# Altium schematic sheet Power system 1.SchDoc
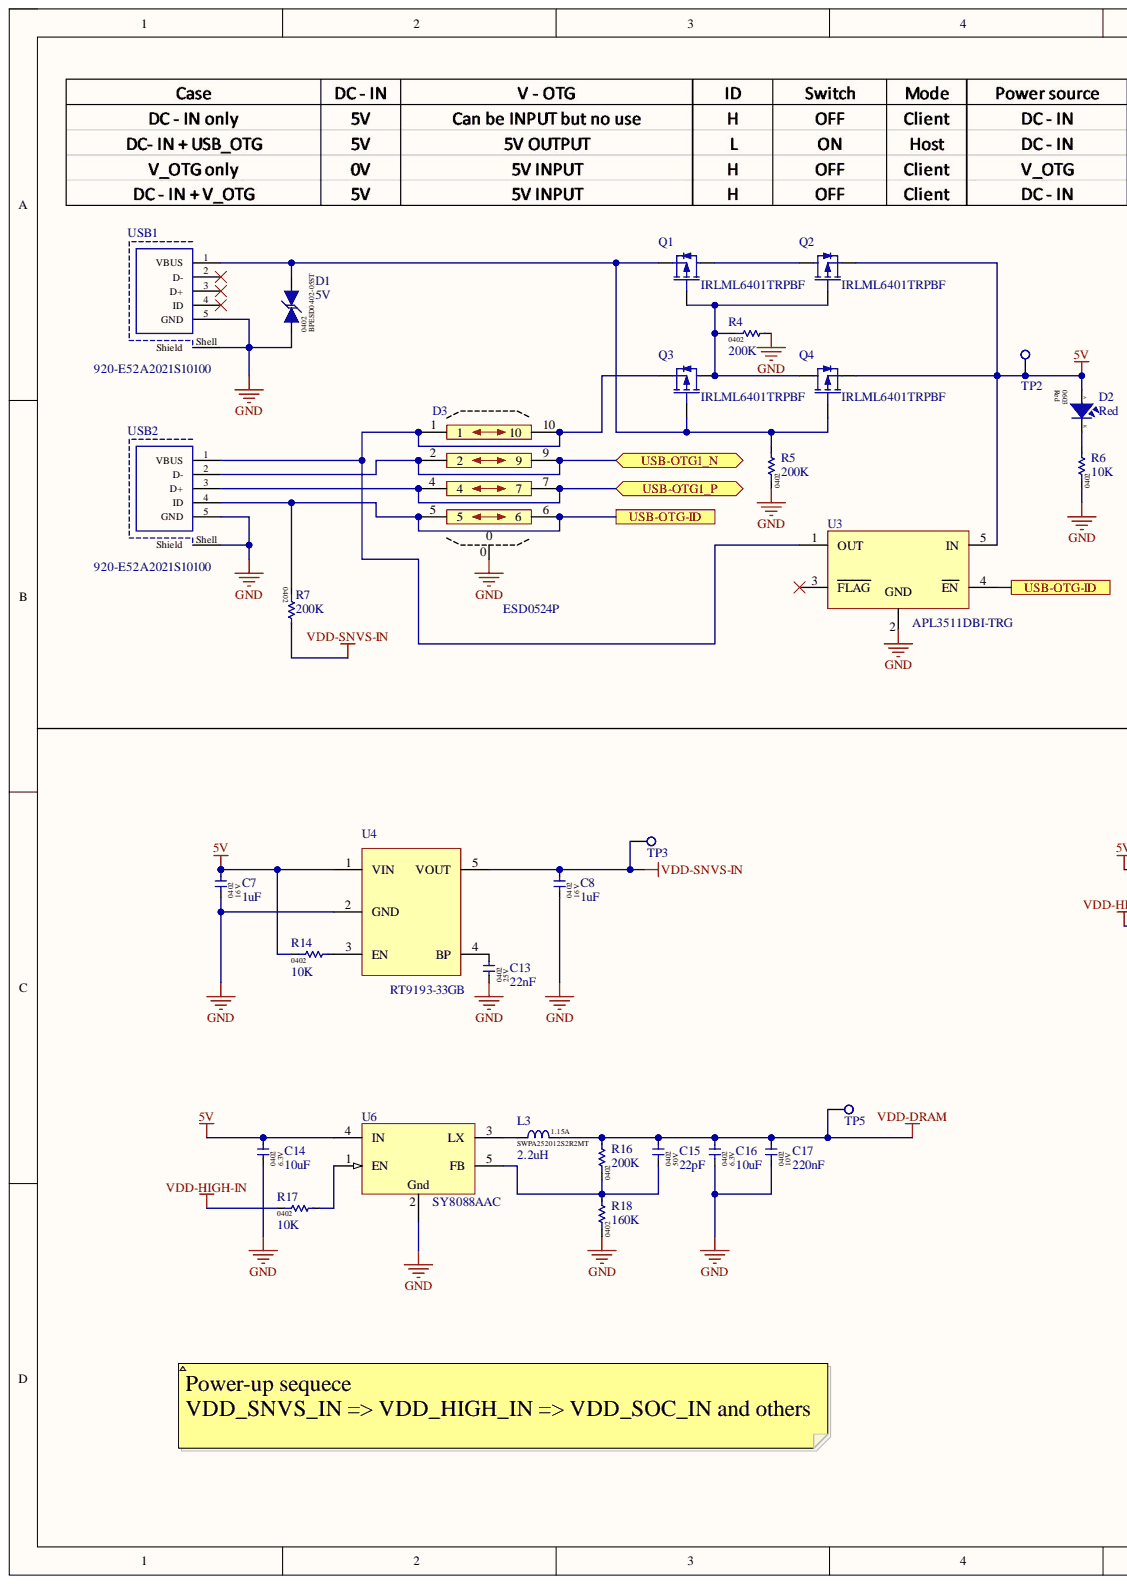
[diagram p0: Power system 1.SchDoc, left]
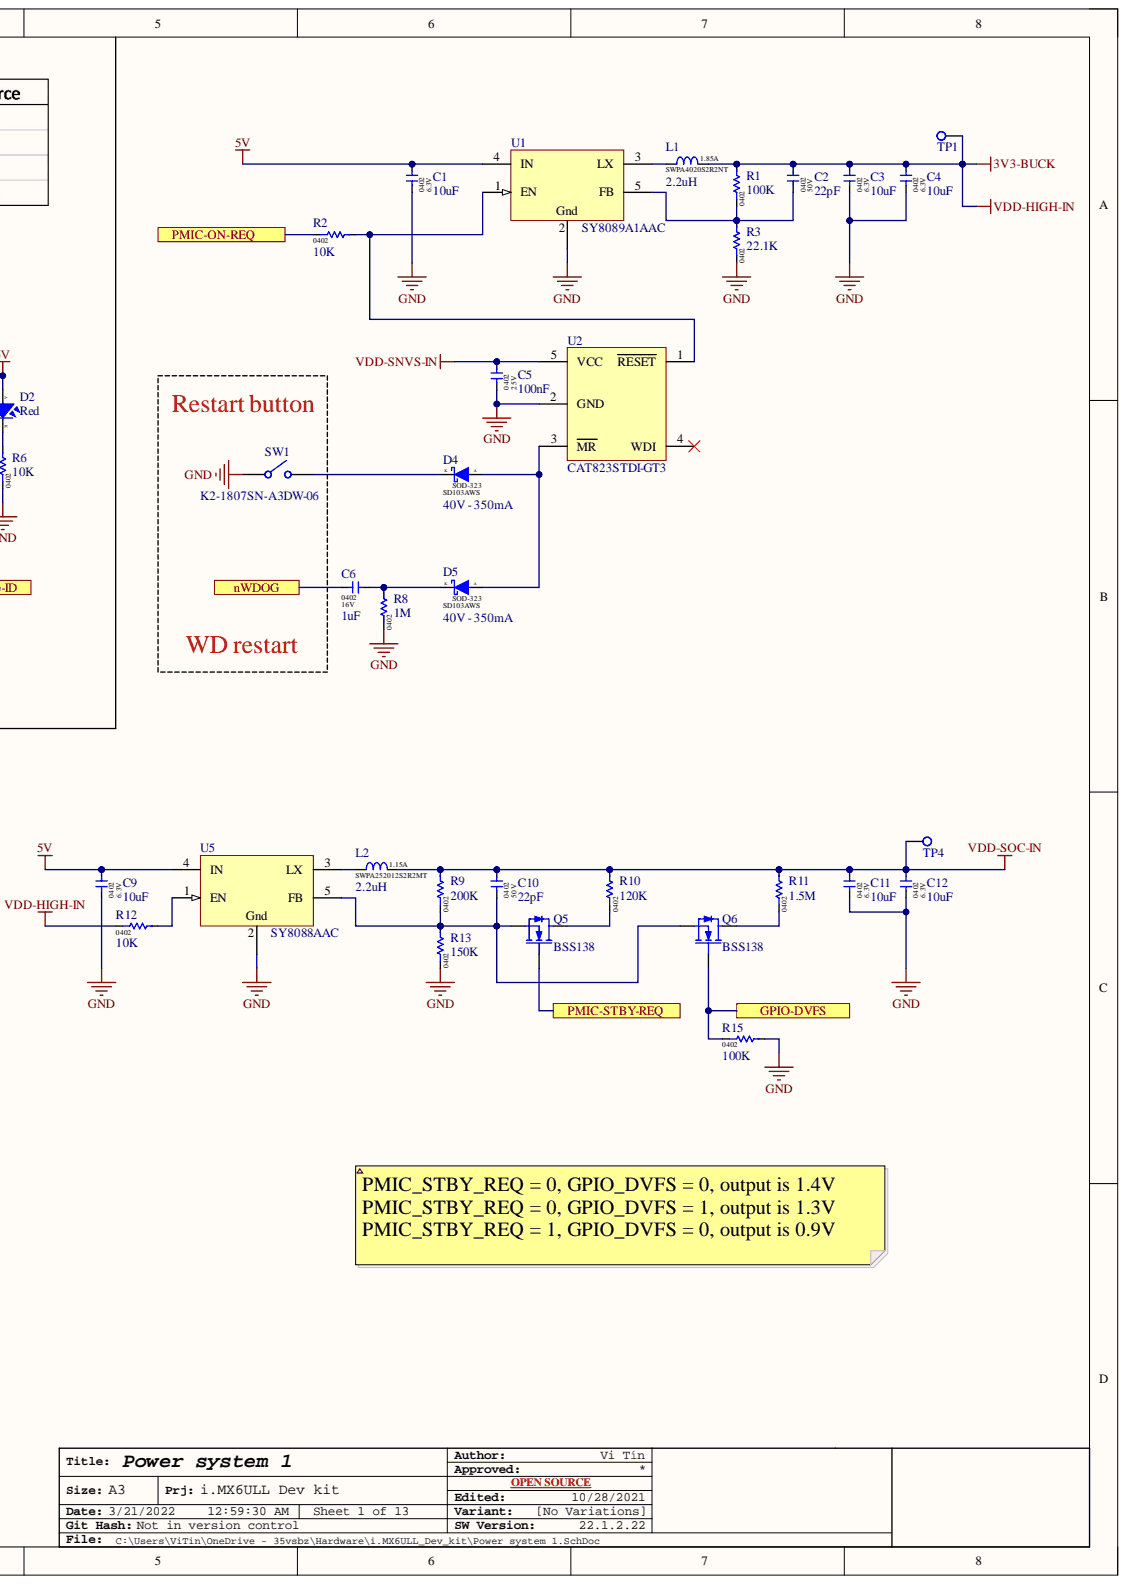
[diagram p1: Power system 1.SchDoc, right]

## Parts
C1  10uF  Package: 0402  Voltage: 6.3V  [CAP-0402]
C2  22pF  Package: 0402  Voltage: 50V  [CAP-0402]
C3  10uF  Package: 0402  Voltage: 6.3V  [CAP-0402]
C4  10uF  Package: 0402  Voltage: 6.3V  [CAP-0402]
C5  100nF  Package: 0402  Voltage: 25V  [CAP-0402]
C6  1uF  Package: 0402  Voltage: 16V  [CAP-0402]
C7  1uF  Package: 0402  Voltage: 16V  [CAP-0402]
C8  1uF  Package: 0402  Voltage: 16V  [CAP-0402]
C9  10uF  Package: 0402  Voltage: 6.3V  [CAP-0402]
C10  22pF  Package: 0402  Voltage: 50V  [CAP-0402]
C11  10uF  Package: 0402  Voltage: 6.3V  [CAP-0402]
C12  10uF  Package: 0402  Voltage: 6.3V  [CAP-0402]
C13  22nF  Package: 0402  Voltage: 25V  [CAP-0402]
C14  10uF  Package: 0402  Voltage: 6.3V  [CAP-0402]
C15  22pF  Package: 0402  Voltage: 50V  [CAP-0402]
C16  10uF  Package: 0402  Voltage: 6.3V  [CAP-0402]
C17  220nF  Package: 0402  Voltage: 10V  [CAP-0402]
D1  5V  Package: 0402  [Diode-0402]
D2  Red  Package: 0603  [LED-0603 RED]
D3  ESD0524P  [DFN2510]
D4  40V - 350mA  Package: SOD-323  [SOD323 (UNI)]
D5  40V - 350mA  Package: SOD-323  [SOD323 (UNI)]
L1  2.2uH  Irms max: 1.85A  [IND-4020]
L2  2.2uH  Irms max: 1.15A  [IND-252012]
L3  2.2uH  Irms max: 1.15A  [IND-252012]
Q1  IRLML6401TRPBF  [SOT23-3]
Q2  IRLML6401TRPBF  [SOT23-3]
Q3  IRLML6401TRPBF  [SOT23-3]
Q4  IRLML6401TRPBF  [SOT23-3]
Q5  BSS138  [SOT23-3]
Q6  BSS138  [SOT23-3]
R1  100K  Package: 0402  [RES-0402]
R2  10K  Package: 0402  [RES-0402]
R3  22.1K  Package: 0402  [RES-0402]
R4  200K  Package: 0402  [RES-0402]
R5  200K  Package: 0402  [RES-0402]
R6  10K  Package: 0402  [RES-0402]
R7  200K  Package: 0402  [RES-0402]
R8  1M  Package: 0402  [RES-0402]
R9  200K  Package: 0402  [RES-0402]
R10  120K  Package: 0402  [RES-0402]
R11  1.5M  Package: 0402  [RES-0402]
R12  10K  Package: 0402  [RES-0402]
R13  150K  Package: 0402  [RES-0402]
R14  10K  Package: 0402  [RES-0402]
R15  100K  Package: 0402  [RES-0402]
R16  200K  Package: 0402  [RES-0402]
R17  10K  Package: 0402  [RES-0402]
R18  160K  Package: 0402  [RES-0402]
SW1  K2-1807SN-A3DW-06  [SW - TYPE 3]
TP1  N/A  [Test point]  (value not drawn)  (no BOM)
TP2  N/A  [Test point]  (value not drawn)  (no BOM)
TP3  N/A  [Test point]  (value not drawn)  (no BOM)
TP4  N/A  [Test point]  (value not drawn)  (no BOM)
TP5  N/A  [Test point]  (value not drawn)  (no BOM)
U1  SY8089A1AAC  [SOT23-5]
U2  CAT823STDI-GT3  [SOT23-5]
U3  APL3511DBI-TRG  [SOT23-5]
U4  RT9193-33GB  [SOT23-5]
U5  SY8088AAC  [SOT23-5]
U6  SY8088AAC  [SOT23-5]
USB1  920-E52A2021S10100  [Micro USB - type 2]
USB2  920-E52A2021S10100  [Micro USB - type 2]

## Ports
GPIO-DVFS, nWDOG, PMIC-ON-REQ, PMIC-STBY-REQ, USB-OTG1_N, USB-OTG1_P, USB-OTG-ID

## Nets
3V3-BUCK: C2.2 C3.2 C4.2 L1.2 R1.2 R12.1 R17.1 TP1.1
5V: C1.2 C7.2 C9.2 C14.2 D2.2 Q2.2 Q4.2 R14.1 TP2.1 U1.4(IN) U3.5(IN) U4.1(VIN) U5.4(IN) U6.4(IN)
GND: C1.1 C3.1 C4.1 C5.1 C7.1 C8.1 C9.1 C11.1 C12.1 C13.1 C14.1 C16.1 C17.1 D1.1 D3.0 R3.1 R4.2 R5.1 R6.1 R8.1 R13.1 R15.2 R18.1 SW1.1 U1.2 U2.2(GND) U3.2 U4.2(GND) U5.2 U6.2 USB1.5(GND) USB1.Shell(Shield) USB2.5(GND) USB2.Shell(Shield)
VDD-DRAM: C15.2 C16.2 C17.2 L3.2 R16.2 TP5.1
VDD-SNVS-IN: C5.2 C8.2 R7.2 TP3.1 U2.5(VCC) U4.5(VOUT)
VDD-SOC-IN: C10.2 C11.2 C12.2 L2.2 R9.2 R10.2 R11.2 TP4.1
GPIO-DVFS: Q6.1 R15.1 ; port GPIO-DVFS
nWDOG: C6.1 ; port nWDOG
PMIC-ON-REQ: R2.1 ; port PMIC-ON-REQ
PMIC-STBY-REQ: Q5.1 ; port PMIC-STBY-REQ
USB-OTG1_N: D3.2 D3.9 USB2.2(D-) ; port USB-OTG1_N
USB-OTG1_P: D3.4 D3.7 USB2.3(D+) ; port USB-OTG1_P
USB-OTG-ID: D3.5 D3.6 R7.1 U3.4(EN) USB2.4(ID) ; port USB-OTG-ID
NetC2_1: C2.1 R1.1 R3.2 U1.5(FB)
NetC6_2: C6.2 D5.1 R8.2
NetC10_1: C10.1 Q5.2 Q6.2 R9.1 R13.2 U5.5(FB)
NetC13_2: C13.2 U4.4(BP)
NetC15_1: C15.1 R16.1 R18.2 U6.5(FB)
NetD1_2: D1.2 Q1.2 Q3.1 Q4.1 R5.2 USB1.1(VBUS)
NetD2_1: D2.1 R6.2
NetD3_1: D3.1 D3.10 Q3.2 U3.1(OUT) USB2.1(VBUS)
NetD4_1: D4.1 SW1.2
NetD4_2: D4.2 D5.2 U2.3(MR)
NetL1_1: L1.1 U1.3(LX)
NetL2_1: L2.1 U5.3(LX)
NetL3_1: L3.1 U6.3(LX)
NetQ1_1: Q1.1 Q2.1 Q3.3 Q4.3 R4.1
NetQ1_3: Q1.3 Q2.3
NetQ5_3: Q5.3 R10.1
NetQ6_3: Q6.3 R11.1
NetR2_2: R2.2 U1.1(EN) U2.1(RESET)
NetR12_2: R12.2 U5.1(EN)
NetR14_2: R14.2 U4.3(EN)
NetR17_2: R17.2 U6.1(EN)

Not connected: U2 4(WDI); U3 3(FLAG); USB1 2(D-) 3(D+) 4(ID)

## Library data of the parts
C1 C3 C4 C9 C11 C12 C14 C16 = 0402X106M6R3CT: 10uF ±20% 6.3V X5R 0402 Multilayer Ceramic Capacitors MLCC - SMD/SMT RoHS; Manufacture: WALSIN; Database Table Name: Sheet1$; Capacitor value: 10uF; Component Kind: Standard; Component type: Capacitor; Library Name: Capacitor Database.DbLib; Manufacture part: 0402X106M6R3CT; Package / Case: 0402
C2 C10 C15 = 0402N220J500CT: 22pF ±5% 50V NP0 0402 Multilayer Ceramic Capacitors MLCC - SMD/SMT RoHS; Manufacture: WALSIN; Database Table Name: Sheet1$; Capacitor value: 22pF; Component Kind: Standard; Component type: Capacitor; Library Name: Capacitor Database.DbLib; Manufacture part: 0402N220J500CT; Package / Case: 0402
C5 = 0402X104K250CT: 100nF ±10% 25V X5R 0402 Multilayer Ceramic Capacitors MLCC - SMD/SMT RoHS; Manufacture: WALSIN; Database Table Name: Sheet1$; Capacitor value: 100nF; Component Kind: Standard; Component type: Capacitor; Library Name: Capacitor Database.DbLib; Manufacture part: 0402X104K250CT; Package / Case: 0402
C6-C8 = 0402X105K160CT: 1uF ±10% 16V X5R 0402 Multilayer Ceramic Capacitors MLCC - SMD/SMT RoHS; Manufacture: WALSIN; Database Table Name: Sheet1$; Capacitor value: 1uF; Component Kind: Standard; Component type: Capacitor; Library Name: Capacitor Database.DbLib; Manufacture part: 0402X105K160CT; Package / Case: 0402
C13 = 0402B223K250CT: 22nF ±10% 25V X7R 0402 Multilayer Ceramic Capacitors MLCC - SMD/SMT RoHS; Manufacture: WALSIN; Database Table Name: Sheet1$; Capacitor value: 22nF; Component Kind: Standard; Component type: Capacitor; Library Name: Capacitor Database.DbLib; Manufacture part: 0402B223K250CT; Package / Case: 0402
C17 = 0402X224J100CT: 220nF ±5% 10V X5R 0402 Multilayer Ceramic Capacitors MLCC - SMD/SMT RoHS; Manufacture: WALSIN; Database Table Name: Sheet1$; Capacitor value: 220nF; Component Kind: Standard; Component type: Capacitor; Library Name: Capacitor Database.DbLib; Manufacture part: 0402X224J100CT; Package / Case: 0402
D1 = BPESD0402-05ST: Bidirectional 40V 5V (Max) 0402 ESD Protection Devices ROHS; Manufacture: BORN; Database Table Name: Sheet1$; Type: TVS/ESD Bi diode; Component Kind: Standard; Datasheet: https://datasheet.lcsc.com/lcsc/1811031631_BORN-BPESD0402-05ST_C316059.pdf; Diode value: 5V; Library Name: Diode Database.DbLib; Manufacture part: BPESD0402-05ST
D2 = 19-217/R6C-AL1M2VY/3T: Red 617.5~633.5nm 0603 Light Emitting Diodes (LED) RoHS; Manufacture: Everlight Elec; Database Table Name: Sheet1$; Color: Red; Component Kind: Standard; Component type: Led; Library Name: Led Database.DbLib; Manufacture part: 19-217/R6C-AL1M2VY/3T; Package / Case: 0402
D3 = ESD0524P: DFN2510 ESD Protection Devices ROHS; Manufacture: MDD（Microdiode Electronics）; Database Table Name: Sheet1$; Diode value: 5V; Type: TVS/ESD Bi diode; Component Kind: Standard; Datasheet: https://datasheet.lcsc.com/lcsc/2009281808_MDD-Microdiode-Electronics--ESD0524P_C840786.pdf; Library Name: Diode Database.DbLib; Manufacture part: ESD0524P; Package: DFN2510
D4 D5 = SD103AWS: 40V 350mA 600mV @ 200mA SOD-323 Schottky Barrier Diodes (SBD) ROHS; Manufacture: Shikues; Database Table Name: Sheet1$; Type: Schottky diode; Component Kind: Standard; Datasheet: https://datasheet.lcsc.com/lcsc/1809211226_Shikues-SD103AWS_C109204.pdf; Diode value: 40V - 350mA; Library Name: Diode Database.DbLib; Manufacture part: SD103AWS
L1 = SWPA4020S2R2NT: Inductor 40x40x20, 2.2±30%  , 1.85A; Manufacture: SUNLORD; Database Table Name: Sheet1$; DCR: 0.04 Ω; Component Kind: Standard; Inductance value: 2.2uH; Library Name: Inductor Database.DbLib; Manufacture part: SWPA4020S2R2NT; Package: 4020
L2 L3 = SWPA252012S2R2MT: Inductor 25x20x12,  2.2±20%, 1.15A; Manufacture: SUNLORD; Database Table Name: Sheet1$; DCR: 0.216 Ω; Component Kind: Standard; Inductance value: 2.2uH; Library Name: Inductor Database.DbLib; Manufacture part: SWPA252012S2R2MT; Package: 252012
Q1-Q4 = IRLML6401TRPBF: P-Channel 12V 4.3A 950mV @ 250uA 50mΩ @ 4.3A,4.5V 1.3W SOT-23(SOT-23-3) MOSFETs RoHS; Manufacture: Infineon Technologies; Database Table Name: Sheet1$; Type: P-Mosfet; Component Kind: Standard; Datasheet: https://datasheet.lcsc.com/lcsc/International-Rectifier-IR-IRLML6401TRPBF_C3034.pdf; Library Name: Transistor Database.DbLib; Manufacture part: IRLML6401TRPBF; Package: SOT23-3
Q5 Q6 = BSS138: N-Channel 50V 220mA 1.5V @ 1mA 3.5Ω @ 220mA,10V 360mW SOT-23(SOT-23-3) MOSFETs RoHS; Manufacture: Shikues; Database Table Name: Sheet1$; Type: N-Mosfet; Component Kind: Standard; Datasheet: https://datasheet.lcsc.com/lcsc/1811101310_Shikues-BSS138_C112239.pdf; Library Name: Transistor Database.DbLib; Manufacture part: BSS138; Package: SOT23-3
R1 R15 = WR04X1003FTL: 100kΩ ±1% 1/16W ±100ppm/℃ 0402 Chip Resistor - Surface Mount RoHS; Manufacture: WALSIN; Database Table Name: Sheet1$; Component Kind: Standard; Component type: Resistor; Library Name: Resistor Database.DbLib; Manufacture part: WR04X1003FTL; Package / Case: 0201; Resistor value: 100K
R2 R6 R12 R14 R17 = WR04X1002FTL: 10kΩ ±1% 1/16W ±100ppm/℃ 0402 Chip Resistor - Surface Mount RoHS; Manufacture: WALSIN; Database Table Name: Sheet1$; Component Kind: Standard; Component type: Resistor; Library Name: Resistor Database.DbLib; Manufacture part: WR04X1002FTL; Package / Case: 0201; Resistor value: 10K
R3 = WR04X2212FTL: 22.1kΩ ±1% 1/16W ±100ppm/℃ 0402 Chip Resistor - Surface Mount RoHS; Manufacture: WALSIN; Database Table Name: Sheet1$; Component Kind: Standard; Component type: Resistor; Library Name: Resistor Database.DbLib; Manufacture part: WR04X2212FTL; Package / Case: 0201; Resistor value: 22.1K
R4 R5 R7 R9 R16 = WR04X2003FTL: 200kΩ ±1% 1/16W ±100ppm/℃ 0402 Chip Resistor - Surface Mount RoHS; Manufacture: WALSIN; Database Table Name: Sheet1$; Component Kind: Standard; Component type: Resistor; Library Name: Resistor Database.DbLib; Manufacture part: WR04X2003FTL; Package / Case: 0201; Resistor value: 200K
R8 = WR04X1004FTL: 1MΩ ±1% 1/16W ±100ppm/℃ 0402 Chip Resistor - Surface Mount RoHS; Manufacture: WALSIN; Database Table Name: Sheet1$; Component Kind: Standard; Component type: Resistor; Library Name: Resistor Database.DbLib; Manufacture part: WR04X1004FTL; Package / Case: 0201; Resistor value: 1M
R10 = WR04X1203FTL: 120kΩ ±1% 1/16W ±100ppm/℃ 0402 Chip Resistor - Surface Mount RoHS; Manufacture: WALSIN; Database Table Name: Sheet1$; Component Kind: Standard; Component type: Resistor; Library Name: Resistor Database.DbLib; Manufacture part: WR04X1203FTL; Package / Case: 0201; Resistor value: 120K
R11 = WR04W1504FTL: 1.5MΩ ±1% 1/16W ±100ppm/℃ 0402 Chip Resistor - Surface Mount RoHS; Manufacture: WALSIN; Database Table Name: Sheet1$; Component Kind: Standard; Component type: Resistor; Library Name: Resistor Database.DbLib; Manufacture part: WR04W1504FTL; Package / Case: 0201; Resistor value: 1.5M
R13 = WR04X1503FTL: 150kΩ ±1% 1/16W ±100ppm/℃ 0402 Chip Resistor - Surface Mount RoHS; Manufacture: WALSIN; Database Table Name: Sheet1$; Component Kind: Standard; Component type: Resistor; Library Name: Resistor Database.DbLib; Manufacture part: WR04X1503FTL; Package / Case: 0201; Resistor value: 150K
R18 = WR04X1603FTL: 160kΩ ±1% 1/16W ±100ppm/℃ 0402 Chip Resistor - Surface Mount RoHS; Manufacture: WALSIN; Database Table Name: Sheet1$; Component Kind: Standard; Component type: Resistor; Library Name: Resistor Database.DbLib; Manufacture part: WR04X1603FTL; Package / Case: 0201; Resistor value: 160K
SW1 = K2-1807SN-A3DW-06: SMD,3×4×2.0mm Tactile Switches RoHS; Manufacture: Korean Hroparts Elec; Database Table Name: Sheet1$; Component Kind: Standard; Datasheet: https://datasheet.lcsc.com/lcsc/2008221234_XUNPU-TS-1088-AR02016_C720477.pdf; Library Name: Connectors, Misc.DbLib; Manufacture part: K2-1807SN-A3DW-06; Type: Tactile Switches
TP1-TP5 = N/A: A point used for measure.; Database Table Name: Sheet1$; Library Name: Connectors, Misc.DbLib; Manufacture: N/A; Manufacture part: N/A; Type: Test point
U1 = SY8089A1AAC: 5.5V 2A SOT-23-5 DC-DC Converters RoHS; Manufacture: Richtek Tech; Database Table Name: Sheet1$; Component Kind: Standard; Datasheet: https://datasheet.lcsc.com/lcsc/2001171834_Silergy-Corp-SY8089A1AAC_C479074.pdf; Library Name: Regulator Database.DbLib; Manufacture part: SY8089A1AAC; Package: SOT23-5; Type: Buck
U2 = CAT823STDI-GT3: 2.93V Monitors & Reset Circuits with manual reset and watchdog; Manufacture: ON Semiconductor; Database Table Name: Sheet1$; Component Kind: Standard; Datasheet: https://datasheet.lcsc.com/lcsc/ON-Semicon-ON-CAT823STDI-GT3_C91362.pdf; Library Name: IC Database.DbLib; Manufacture part: CAT823STDI-GT3; Package: SOT23-5
U3 = APL3511DBI-TRG: 5.5V, 1.5A Power Distribution Switches ROHS; Manufacture: Anpec Elec; Database Table Name: Sheet1$; Component Kind: Standard; Datasheet: https://datasheet.lcsc.com/lcsc/1912111437_Anpec-Elec-APL3511DBI-TRG_C351148.pdf; Library Name: IC Database.DbLib; Manufacture part: APL3511DBI-TRG; Package: SOT23-5
U4 = RT9193-33GB: Fixed 5.5V 3.3V 300mV @ 300mA SOT-23-5 Dropout Regulators(LDO) RoHS; Manufacture: Richtek Tech; Database Table Name: Sheet1$; Component Kind: Standard; Datasheet: https://datasheet.lcsc.com/lcsc/Richtek-Tech-RT9193-28GB_C56731.pdf; Library Name: Regulator Database.DbLib; Manufacture part: RT9193-33GB; Package: SOT23-5; Type: LDO
U5 U6 = SY8088AAC: 5.5V 1A SOT-23-5 DC-DC Converters RoHS; Manufacture: Richtek Tech; Database Table Name: Sheet1$; Component Kind: Standard; Datasheet: https://datasheet.lcsc.com/lcsc/Silergy-Corp-SY8088AAC_C79313.pdf; Library Name: Regulator Database.DbLib; Manufacture part: SY8088AAC; Package: SOT23-5; Type: Buck
USB1 USB2 = 920-E52A2021S10100: USB - Micro B Female USB 2 USB Connectors RoHS; Manufacture: Jing Extension of the Electronic Co.; Database Table Name: Sheet1$; Component Kind: Standard; Datasheet: https://datasheet.lcsc.com/lcsc/2103051832_Jing-Extension-of-the-Electronic-Co-920-E52A2021S10100_C10418.pdf; Library Name: Connectors, Misc.DbLib; Manufacture part: 920-E52A2021S10100; Type: USB-Micro B
